# Altium schematic sheet HK32F030MF4P6_Header_Board.SchDoc(HK32F030MF4P6_Header_Board)
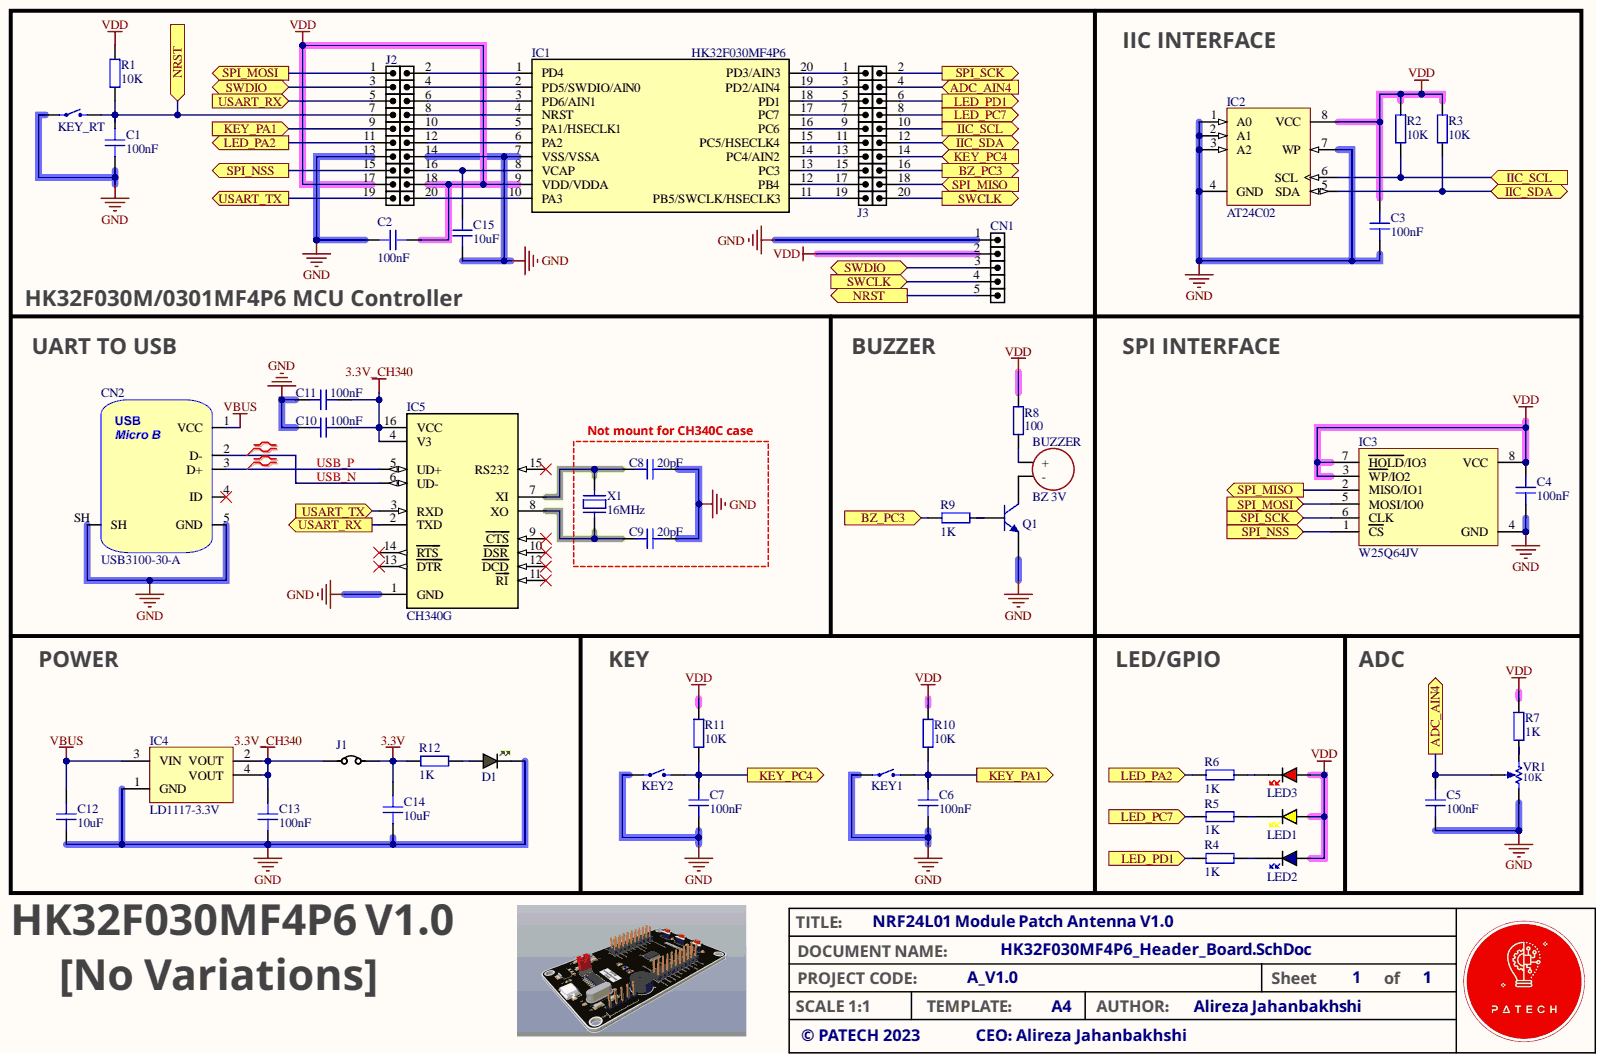
[diagram p0: HK32F030MF4P6_Header_Board.SchDoc]

## Parts
BUZZER  BZ 3V  [BUZ_9MM]
C1  100nF  [CAP_1206]
C2  100nF  [CAP_1206]
C3  100nF  [CAP_1206]
C4  100nF  [CAP_1206]
C5  100nF  [CAP_1206]
C6  100nF  [CAP_1206]
C7  100nF  [CAP_1206]
C8  20pF  [CAP_1206]
C9  20pF  [CAP_1206]
C10  100nF  [CAP_1206]
C11  100nF  [CAP_1206]
C12  10uF  [CAP_1206]
C13  100nF  [CAP_1206]
C14  10uF  [CAP_1206]
C15  10uF  [CAP_1206]
CN1  HDR1X5  [HDR_M_1X5]  (value not drawn)
CN2  USB3100-30-A  [USB3100-30-A]
D1  GREEN  [LED_0603_GREEN]  (value not drawn)
IC1  HK32F030MF4P6  [TSSOP-20_L6.5-W4.4-P0.65-LS6.4-BL]
IC2  AT24C02  [SOIC-8 4.9X3.9MM P1.27MM]
IC3  W25Q64JV  [SOIC-8 5.28X5.28MM P1.27MM]
IC4  LD1117-3.3V  [SOT-223-4 6.5X7MM P2.3MM]
IC5  CH340G  [SOIC127P600X180-16N]
J1  JMPHDR2PIN  [JP_2_RED]  (value not drawn)
J2  HDRM_2X10  [HDR_M_2X10]  (value not drawn)
J3  HDRM_2X10  [HDR_M_2X10]  (value not drawn)
KEY1  Tactile 2PIN 6X3.5mm H4.57mm (SMD)  [SWPBS_SPST_6X3X5]  (value not drawn)
KEY2  Tactile 2PIN 6X3.5mm H4.57mm (SMD)  [SWPBS_SPST_6X3X5]  (value not drawn)
KEY_RT  Tactile 2PIN 6X3.5mm H4.57mm (SMD)  [SWPBS_SPST_6X3X5]  (value not drawn)
LED1  YELLOW  [LED_0603_YELLOW]  (value not drawn)
LED2  BLUE  [LED_0603_BLUE]  (value not drawn)
LED3  RED  [LED_0603_RED]  (value not drawn)
Q1  8050  [SOT-23-3 2.9X2.4MM P0.95MM]  (value not drawn)
R1  10K  [RES_1206]
R2  10K  [RES_1206]
R3  10K  [RES_1206]
R4  1K  [RES_1206]
R5  1K  [RES_1206]
R6  1K  [RES_1206]
R7  1K  [RES_1206]
R8  100  [RES_1206]
R9  1K  [RES_1206]
R10  10K  [RES_1206]
R11  10K  [RES_1206]
R12  1K  [RES_1206]
VR1  10K  [POT SMD 3]
X1  16MHz  [HC-49S SMD]

## Ports
ADC_AIN4, BZ_PC3, IIC_SCL, IIC_SDA, KEY_PA1, KEY_PC4, LED_PA2, LED_PC7, LED_PD1, NRST, SPI_MISO, SPI_MOSI, SPI_NSS, SPI_SCK, SWCLK, SWDIO, USART_RX, USART_TX

## Nets
3.3V: C14.2 J1.2 R12.2
3.3V_CH340: C10.1 C11.1 C13.2 IC4.2(VOUT) IC4.4(VOUT) IC5.4(V3) IC5.16(VCC) J1.1
GND: C1.1 C2.1 C3.2 C4.2 C5.2 C6.1 C7.1 C8.2 C9.2 C10.2 C11.2 C12.1 C13.1 C14.1 C15.1 CN1.1 CN2.5(GND) CN2.SH D1.2 IC1.7(VSS/VSSA) IC2.1(A0) IC2.2(A1) IC2.3(A2) IC2.4(GND) IC2.7(WP) IC3.4(GND) IC4.1(GND) IC5.1(GND) J2.13 J2.14 KEY1.1 KEY2.1 KEY_RT.1 Q1.2 VR1.3
VBUS: C12.2 CN2.1(VCC) IC4.3(VIN)
VDD: C2.2 C3.1 C4.1 CN1.2 IC1.9(VDD/VDDA) IC2.8(VCC) IC3.3(WP/IO2) IC3.7(HOLD/IO3) IC3.8(VCC) J2.17 J2.18 LED1.1 LED2.1 LED3.1 R1.2 R2.2 R3.2 R7.2 R8.2 R10.2 R11.2
ADC_AIN4: C5.1 J3.4 VR1.2 ; port ADC_AIN4
BZ_PC3: J3.16 R9.2 ; port BZ_PC3
IIC_SCL: IC2.6(SCL) J3.10 R2.1 ; port IIC_SCL
IIC_SDA: IC2.5(SDA) J3.12 R3.1 ; port IIC_SDA
KEY_PA1: C6.2 J2.9 KEY1.2 R10.1 ; port KEY_PA1
KEY_PC4: C7.2 J3.14 KEY2.2 R11.1 ; port KEY_PC4
LED_PA2: J2.11 R6.2 ; port LED_PA2
LED_PC7: J3.8 R5.2 ; port LED_PC7
LED_PD1: J3.6 R4.2 ; port LED_PD1
NRST: C1.2 CN1.5 J2.7 KEY_RT.2 R1.1 ; port NRST
SPI_MISO: IC3.2(MISO/IO1) J3.18 ; port SPI_MISO
SPI_MOSI: IC3.5(MOSI/IO0) J2.1 ; port SPI_MOSI
SPI_NSS: IC3.1(CS) J2.15 ; port SPI_NSS
SPI_SCK: IC3.6(CLK) J3.2 ; port SPI_SCK
SWCLK: CN1.4 J3.20 ; port SWCLK
SWDIO: CN1.3 J2.3 ; port SWDIO
USART_RX: IC5.2(TXD) J2.5 ; port USART_RX
USART_TX: IC5.3(RXD) J2.19 ; port USART_TX
USB_N: CN2.2(D-) IC5.6(UD-)
USB_P: CN2.3(D+) IC5.5(UD+)
NetBUZZER_1: BUZZER.1 R8.1
NetBUZZER_2: BUZZER.2 Q1.3
NetC8_1: C8.1 IC5.7(XI) X1.2
NetC9_1: C9.1 IC5.8(XO) X1.1
NetC15_2: C15.2 IC1.8(VCAP) J2.16
NetD1_1: D1.1 R12.1
NetIC1_1: IC1.1(PD4) J2.2
NetIC1_2: IC1.2(PD5/SWDIO/AIN0) J2.4
NetIC1_3: IC1.3(PD6/AIN1) J2.6
NetIC1_4: IC1.4(NRST) J2.8
NetIC1_5: IC1.5(PA1/HSECLK1) J2.10
NetIC1_6: IC1.6(PA2) J2.12
NetIC1_10: IC1.10(PA3) J2.20
NetIC1_11: IC1.11(PB5/SWCLK/HSECLK3) J3.19
NetIC1_12: IC1.12(PB4) J3.17
NetIC1_13: IC1.13(PC3) J3.15
NetIC1_14: IC1.14(PC4/AIN2) J3.13
NetIC1_15: IC1.15(PC5/HSECLK4) J3.11
NetIC1_16: IC1.16(PC6) J3.9
NetIC1_17: IC1.17(PC7) J3.7
NetIC1_18: IC1.18(PD1) J3.5
NetIC1_19: IC1.19(PD2/AIN4) J3.3
NetIC1_20: IC1.20(PD3/AIN3) J3.1
NetLED1_2: LED1.2 R5.1
NetLED2_2: LED2.2 R4.1
NetLED3_2: LED3.2 R6.1
NetQ1_1: Q1.1 R9.1
NetR7_1: R7.1 VR1.1

Not connected: CN2 4(ID); IC5 9(CTS) 10(DSR) 11(RI) 12(DCD) 13(DTR) 14(RTS) 15(RS232)

## Library data of the parts
BUZZER = Buzzer 3V 9mm (THD): Buzzers Transducer, Externally Driven Magnetic 3V 80mA 2.73kHz 85dB @ 3V, 10cm Through Hole PC Pins; PartNumber: CST-931AP; Component Kind: Standard; Library Name: Buzzer Microphone.IntLib
C1-C15 = CAP_1206_10V: Capacitor SMD 1206 10V; Component Kind: Standard; Library Name: Schematic-Lib-PhilsLab.SchLib
CN1 = HDR_M_1X5: CONN, HEADER, Male, pitch: 2.54mm, 5POS; VALUE: HDRM5; Component Kind: Standard; Library Name: Conn Header.IntLib
CN2 = USB3100-30-A: USB Connector Type Micro B 2.0 SMD Reverse Mount; Manufacturer: GCT; Component Kind: Standard; Manufacturer Part Number: USB3100-30-A; Library Name: Schematic-Lib-PhilsLab.SchLib
D1 = LED_0603_GREEN: LED 0603 GREEN SMD; Component Kind: Standard; Library Name: Schematic-Lib-PhilsLab.SchLib
IC1 = HK32F030MF4P6_1: BOM_Manufacturer: HK(航顺); Contributor: LCSC; BOM_JLCPCB Part Class: Extended Part; BOM_Manufacturer Part: HK32F030MF4P6; BOM_Supplier: LCSC; BOM_Supplier Part: C707444; Component Kind: Standard; spicePre: U; spiceSymbolName: HK32F030MF4P6; Library Name: Schlibrary.SchLib
IC2 = AT24C02 (SMD SOIC): EEPROM Memory IC 2Kb (128 x 8) I²C 1MHz 550ns (1.7~5.5V)  8-SOIC; PartNumber: AT24C02C-SSHM-T; Component Kind: Standard; Library Name: Chip.IntLib
IC3 = W25Q64 (SMD SOIC W): FLASH - NOR Memory IC 64Mb (8M x 8) SPI - Quad I/O 133MHz  8-SOIC; PartNumber: W25Q64JVSSIM TR; Component Kind: Standard; Library Name: Chip.IntLib
IC4 = LD1117-3.3V (SMD SOT-223): Linear Voltage Regulator IC  1 Output  800mA SOT-223; PartNumber: LD1117S33CTR; Component Kind: Standard; Library Name: Chip.IntLib
IC5 = CH340G: USB Interface Integrated Circuit; MF: WCH; Availability: Not in stock; Check_prices: https://www.snapeda.com/parts/Ch340G/WCH/view-part/?ref=eda; Component Kind: Standard; MP: Ch340G; Package: None; Price: None; SnapEDA_Link: https://www.snapeda.com/parts/Ch340G/WCH/view-part/?ref=snap; Library Name: Schlibrary.SchLib
J1 = JUMPER_HDR_2P: JUMPER, HEADER 2PIN; Component Kind: Standard; Library Name: test-tp_jumper.SchLib; MANF: MANF; MANF_P#: MANF_P#; VALUE: JMPHDR2PIN
J2 J3 = HDR_M_2X10: CONN, HEADER, Male, pitch: 2.54mm, 20POS; Component Kind: Standard; Library Name: Conn Header.IntLib; VALUE: HDRM_2X10
KEY1 KEY2 KEY_RT = Tactile 2PIN 6X3.5mm H4.57mm (SMD): Tactile Switch SPST-NO Top Actuated Surface Mount; PartNumber: FSMSMTR-ND; Component Kind: Standard; Library Name: Switch.IntLib
LED1 = LED_0603_YELLOW: LED 0603 YELLOW SMD; Component Kind: Standard; Library Name: Schematic-Lib-PhilsLab.SchLib
LED2 = LED_0603_BLUE: LED 0603 BLUE SMD; Component Kind: Standard; Library Name: Schematic-Lib-PhilsLab.SchLib
LED3 = LED_0603_RED: LED 0603 RED SMD; Component Kind: Standard; Library Name: Schematic-Lib-PhilsLab.SchLib
Q1 = MMSS8050 (SMD): Bipolar (BJT) Transistor NPN 25V 1.5A 100MHz 625mW Surface Mount SOT-23; PartNumber: MMSS8050-L-TP; Component Kind: Standard; Library Name: Transistor.IntLib
R1-R12 = RES_1206: Resistor SMD 1206; Component Kind: Standard; Library Name: Schematic-Lib-PhilsLab.SchLib
VR1 = Pot 3mm (SMD): Component Kind: Standard; Library Name: Resistor.IntLib; VALUE: 10K
X1 = Crystal HC-49S SMD 8MHz: SMT Crystal; PartNumber: ABLS-8.000MHZ-K4T; Component Kind: Standard; Library Name: Xtal.IntLib
